# Altium schematic sheet Bluetooth.SchDoc
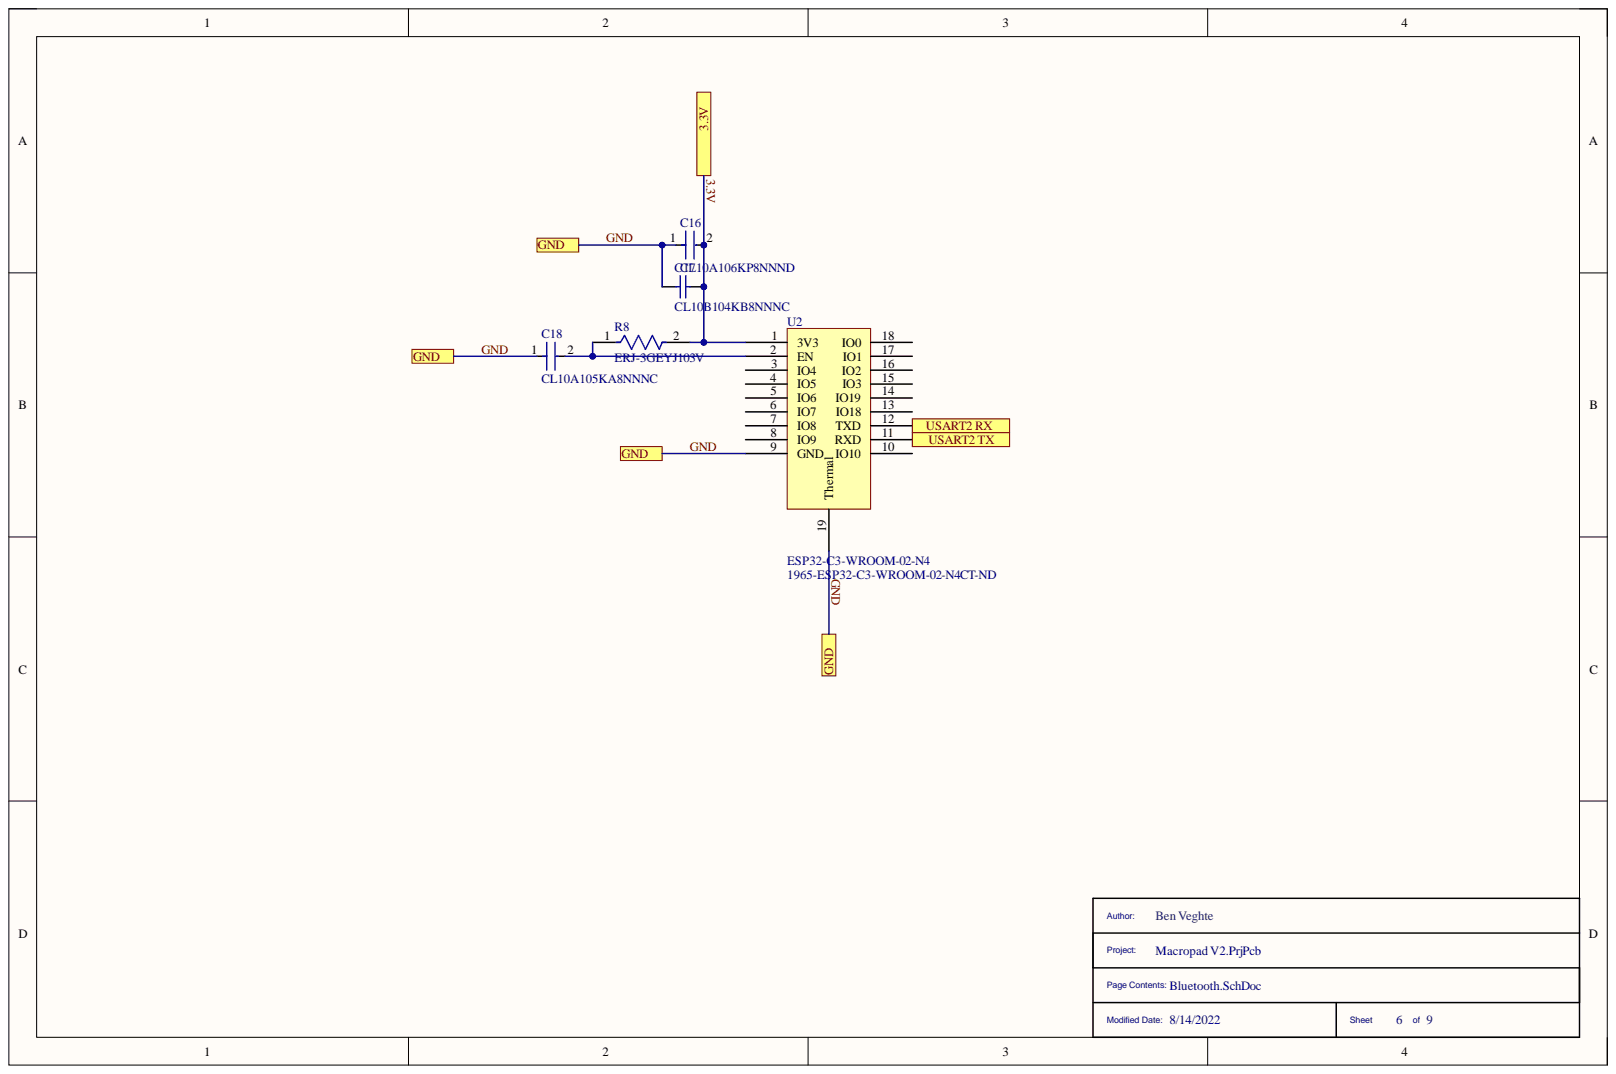
[diagram p0: Bluetooth.SchDoc]

## Parts
C16  CL10A106KP8NNND  [FP-CL10-IPC_B]
C17  CL10B104KB8NNNC  [SAMS-C0603-2_M]
C18  CL10A105KA8NNNC  [FP-CL10-IPC_C]
R8  ERJ-3GEYJ103V  [FP-ERJ3G-IPC_C]
U2  ESP32-C3-WROOM-02-N4  Supplier Part Number 1: 1965-ESP32-C3-WROOM-02-N4CT-ND  [ESP32-C3-WROOM-02-N4]

## Ports
3.3V, GND, USART2 RX, USART2 TX

## Nets
3.3V: C16.2 C17.2 R8.2 U2.1(3V3) ; port 3.3V
GND: C16.1 C17.1 C18.1 U2.9(GND) U2.19(Thermal) ; port GND
USART2 RX: U2.12(TXD) ; port USART2 RX
USART2 TX: U2.11(RXD) ; port USART2 TX
NetC18_2: C18.2 R8.1 U2.2(EN)

Not connected: U2 3(IO4) 4(IO5) 5(IO6) 6(IO7) 7(IO8) 8(IO9) 10(IO10) 13(IO18) 14(IO19) 15(IO3) 16(IO2) 17(IO1) 18(IO0)

## Library data of the parts
C16 = CMP-13271-003245-1: Cap Ceramic 10uF 10V X5R ±10% Pad SMD 0603 +85°C T/R; Capacitance: 10uF; Case/Package: 0603; Component Kind: Standard; Depth: 0.8mm; Dielectric: X5R; Height: 0.8mm; Lead Free: Lead Free; Length: 1.6mm; Library Name: Altium Content Vault; Max Operating Temperature: 85°C; Min Operating Temperature: -55°C; Mount: Surface Mount; Package Quantity: 10000; Packaging: Tape and Reel; Radiation Hardening: No; Thickness: 0.035inch; Tolerance: 10%; Voltage Rating: 10V; Voltage Rating (DC): 10V; Width: 0.031inch
C17 = CMP-2000-05332-1: Multi-layer Ceramic Capacitor, -55 to 125 degC, 2-Pin SMD (0603), RoHS, Tape and Reel; Manufacturer: Samsung Electro-Mechanics; Capacitance: 0.1µF; Dielectric: X7R; Rated Voltage: 50V; Tolerance (%): ±10%; Case Size/Dimension: 0603; Component Kind: Standard; Datasheet URL: https://datasheet.ciiva.com/29198/456651-da-01-en-vs-kondensator-0605-29198839.pdf; Datasheet Version: Nov-10; Library Name: Altium Content Vault; Manufacturer URL: http://www.samsungsem.com/global/index.jsp
C18 = CMP-13271-004127-1: Cap Ceramic 1uF 25V X5R ±10% Pad SMD 0603 +85°C T/R; Capacitance: 1uF; Case Code (Imperial): 0603; Case Code (Metric): 1608; Case/Package: 0603; Component Kind: Standard; Contact Plating: Tin; Depth: 0.8mm; Dielectric: X5R; Height: 0.8mm; Lead Free: Lead Free; Length: 1.6mm; Library Name: Altium Content Vault; Material: Ceramic; Max Operating Temperature: 85°C; Min Operating Temperature: -55°C; Mount: Surface Mount; Package Quantity: 1; Packaging: Tape and Reel; Radiation Hardening: No; Thickness: 0.035inch; Tolerance: 10%; Voltage: 25V; Voltage Rating: 25V; Voltage Rating (DC): 25V; Width: 0.031inch
R8 = CMP-2000-00704-2: RES SMD 10K OHM 5% 1/10W 0603; Max Power Dissipation: 100mW; Resistance: 10kR; Temperature Coefficient: 200ppm/°C; Voltage Rating: 75V; Voltage Rating (DC): 50V; Case Code (Imperial): 0603; Case Code (Metric): 1608; Case/Package: 0603; Component Kind: Standard; Construction: Rectangular; Contact Plating: Tin; Depth: 0.8mm; Features: Automotive AEC-Q200; Height: 0.45mm; Lead Free: Lead Free; Length: 1.6mm; Library Name: Altium Content Vault; Max Operating Temperature: 155°C; Military Standard: Not; Min Operating Temperature: -55°C; Mount: Surface Mount; Number of Terminations: 2; Package Quantity: 1; Packaging: Tape and Reel; Power Rating: 100mW; REACH SVHC: No SVHC; Resistance Tolerance: 5%; Resistor Type: Thick Film; RoHS Compliant: Yes; Series: ERJ; Technology: THICK FILM; Termination: SMD/SMT; Tolerance: 5%; Weight: 7.1E-05oz; Width: 0.8mm; Working Voltage: 75V
U2 = ESP32-C3-WROOM-02-N4: Manufacturer: Espressif Systems; Component Kind: Standard; Manufacturer Part Number: ESP32-C3-WROOM-02-N4; Pricing 1: 1=1.95000 (USD); RoHS: No; Stock 1: 225; Supplier 1: Digi-Key; Library Name: Universal Parts.IntLib
